# Altium schematic sheet POWER.SchDoc(POWER)
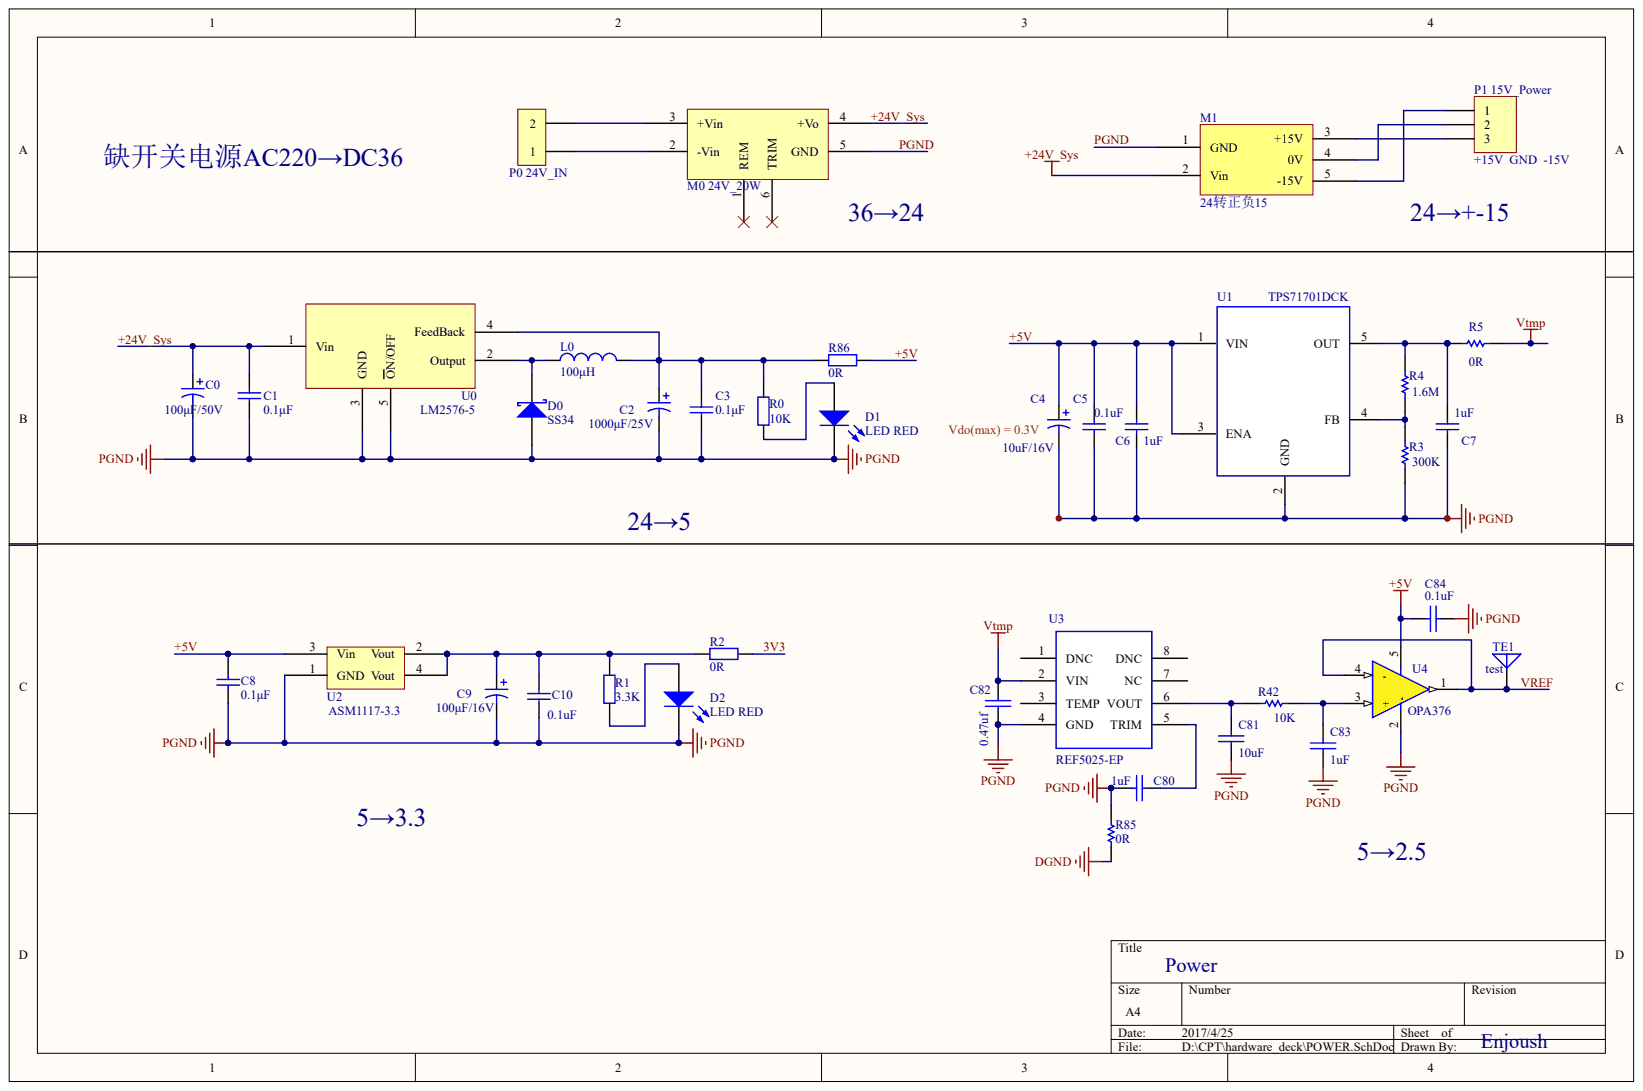
[diagram p0: POWER.SchDoc]

## Parts
C0  100μF/50V
C1  0.1μF
C2  1000μF/25V
C3  0.1μF
C4  10uF/16V
C5  0.1uF
C6  0.1uF  value 1uF
C7  1uF
C8  0.1μF
C9  100μF/16V
C10  value 0.1uF  (hidden comment: Cap)
C80  1uF
C81  10uF
C82  0.47uf
C83  1uF
C84  0.1uF
D0  SS34
D1  LED RED
D2  LED RED
L0  100μH
M0_24V_20W  (no value)
M1  24转正负15
P0_24V_IN  -   +  (value not drawn)
P1_15V_Power  +15V  GND  -15V
R0  10K
R1  3.3K
R2  0R
R3  300K
R4  1.6M
R5  0R
R42  10K
R85  0R
R86  0R
TE1  test
U0  LM2576-5
U1  TPS71701DCK
U2  ASM1117-3.3
U3  REF5025-EP
U4  OPA376

## Nets
DGND: R85.2
VTMP: C82.1 R5.1 U3.2(VIN)
3V3: R2.1
+5V: C4.1 C5.2 C6.2 C8.2 C84.2 R86.2 U1.1(VIN) U1.3(ENA) U2.3(Vin) U4.5
+24V_SYS: C0.1 C1.2 M0_24V_20W.4(+Vo) M1.2(Vin) U0.1(Vin)
PGND: C0.2 C1.1 C2.2 C3.1 C4.2 C5.1 C6.1 C7.1 C8.1 C9.2 C10.1 C80.2 C81.1 C82.2 C83.1 C84.1 D0.1 D1.2 D2.2 M0_24V_20W.5(GND) M1.1(GND) R3.1 R85.1 U0.3(GND) U0.5(ON/OFF) U1.2(GND) U2.1(GND) U3.4(GND) U4.2(-)
VREF: TE1.1 U4.1 U4.4(-)
NetC2_1: C2.1 C3.2 L0.2 R0.1 R86.1 U0.4(FeedBack)
NetC7_2: C7.2 R4.2 R5.2 U1.5(OUT)
NetC9_1: C9.1 C10.2 R1.1 R2.2 U2.2(Vout) U2.4(Vout)
NetC80_1: C80.1 U3.5(TRIM)
NetC81_2: C81.2 R42.2 U3.6(VOUT)
NetC83_2: C83.2 R42.1 U4.3(+)
NetD0_2: D0.2 L0.1 U0.2(Output)
NetD1_1: D1.1 R0.2
NetD2_1: D2.1 R1.2
NetM0 24V_20W_2: M0_24V_20W.2(-Vin) P0_24V_IN.1
NetM0 24V_20W_3: M0_24V_20W.3(+Vin) P0_24V_IN.2
NetM1_3: M1.3(+15V) P1_15V_Power.3
NetM1_4: M1.4(0V) P1_15V_Power.2
NetM1_5: M1.5(-15V) P1_15V_Power.1
NetR3_2: R3.2 R4.1 U1.4(FB)

Not connected: M0_24V_20W 1(REM) 6(TRIM); U3 1(DNC) 3(TEMP) 7(NC) 8(DNC)

## Library data of the parts
C0 = (no symbol name): Value: 100pF; Published: 8-Jun-2000; LatestRevisionDate: 29-May-2009; LatestRevisionNote: IPC-7351 Footprint Added.; PackageReference: C0805; PackageDescription: Chip Capacitor; Publisher: Altium Limited
C1 C3 = (no symbol name): Value: 20pF; Published: 8-Jun-2000; LatestRevisionDate: 17-Jul-2002; LatestRevisionNote: Re-released for DXP Platform.; PackageReference: RAD-0.3; Publisher: Altium Limited
C2 = (no symbol name): Value: 10uF; Published: 8-Jun-2000; LatestRevisionDate: 17-Jul-2002; LatestRevisionNote: Re-released for DXP Platform.; Publisher: Altium Limited
L0 = (no symbol name): Code_IEC: 1005; Value: 10mH; Published: 8-Jun-2000; LatestRevisionDate: 29-May-2009; LatestRevisionNote: IPC-7351 Footprint Added.; PackageReference: 0402-A; PackageDescription: Chip Inductor; Code_JEITA: 0402; Publisher: Altium Limited
R3-R5 R42 R85 = (no symbol name): Value: 1K; Published: 8-Jun-2000; LatestRevisionDate: 17-Jul-2002; LatestRevisionNote: Re-released for DXP Platform.; PackageReference: AXIAL-0.5; Publisher: Altium Limited
C4-C10 C80-C84 D0-D2 M0_24V_20W M1 P0_24V_IN P1_15V_Power R0-R2 R86 TE1 U0-U4 = (no symbol name)
  C4: Published: 8-Jun-2000; LatestRevisionDate: 29-May-2009; LatestRevisionNote: IPC-7351 Footprint Added.; PackageReference: C0805; PackageDescription: Chip Capacitor; Value: 10uF/16V
  C5: Published: 8-Jun-2000; LatestRevisionDate: 17-Jul-2002; LatestRevisionNote: Re-released for DXP Platform.; PackageReference: RAD-0.3; PackageDescription: Radial Cap, Thru-Hole; 2 Leads; 0.3 in Pin Spacing; Value: 0.1uF
  C6 C8 C10: Published: 8-Jun-2000; LatestRevisionDate: 17-Jul-2002; LatestRevisionNote: Re-released for DXP Platform.; PackageReference: RAD-0.3; PackageDescription: Radial Cap, Thru-Hole; 2 Leads; 0.3 in Pin Spacing
  C7: Published: 8-Jun-2000; LatestRevisionDate: 17-Jul-2002; LatestRevisionNote: Re-released for DXP Platform.; PackageReference: RAD-0.3; PackageDescription: Radial Cap, Thru-Hole; 2 Leads; 0.3 in Pin Spacing; Value: 1uF
  C9: Published: 8-Jun-2000; LatestRevisionDate: 29-May-2009; LatestRevisionNote: IPC-7351 Footprint Added.; PackageReference: C0805; PackageDescription: Chip Capacitor
  D0: Published: 8-Jun-2000; LatestRevisionDate: 17-Jul-2002; LatestRevisionNote: Re-released for DXP Platform.; PackageReference: SMB; PackageDescription: DO-214-AA/SMB; 2 C-Bend Leads; Body 5.3 x 3.6 mm, inc. leads (LxW); Code_JEDEC: DO-214-AA
  D1 D2: Published: 8-Jun-2000; LatestRevisionDate: 08-Jul-2005; LatestRevisionNote: Mech Layer 1 removed.; PackageReference: LED-0; PackageDescription: LED; 2 Leads
  P0_24V_IN P1_15V_Power: LatestRevisionDate: 17-Jul-2002; LatestRevisionNote: Re-released for DXP Platform.
  R0-R2 R86: Published: 8-Jun-2000; LatestRevisionDate: 17-Jul-2002; LatestRevisionNote: Re-released for DXP Platform.; PackageReference: AXIAL-0.4; PackageDescription: Axial Device, Thru-Hole; 2 Leads; 0.4 in Pin Spacing
  U4: : U?
  C4-C10 D0-D2 P0_24V_IN P1_15V_Power R0-R2 R86: Publisher: Altium Limited
